# Altium schematic sheet 4 - USB, Power supply.SchDoc
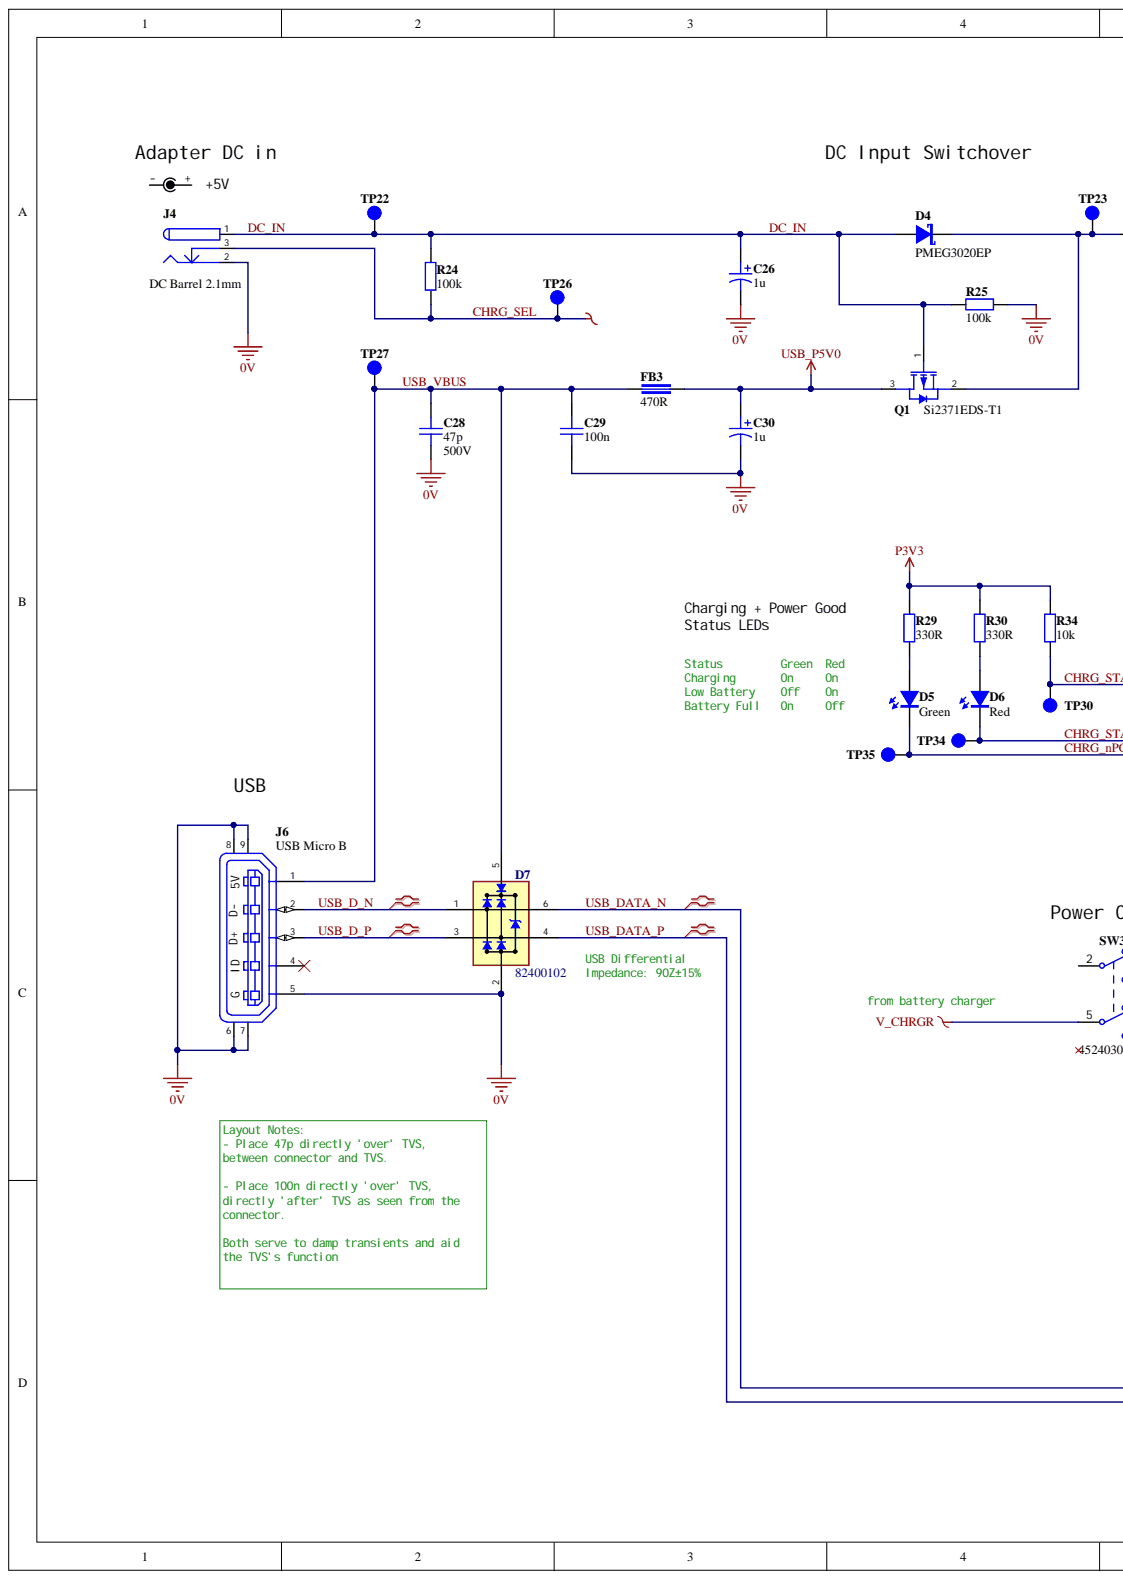
[diagram p0: 4 - USB, Power supply.SchDoc, left]
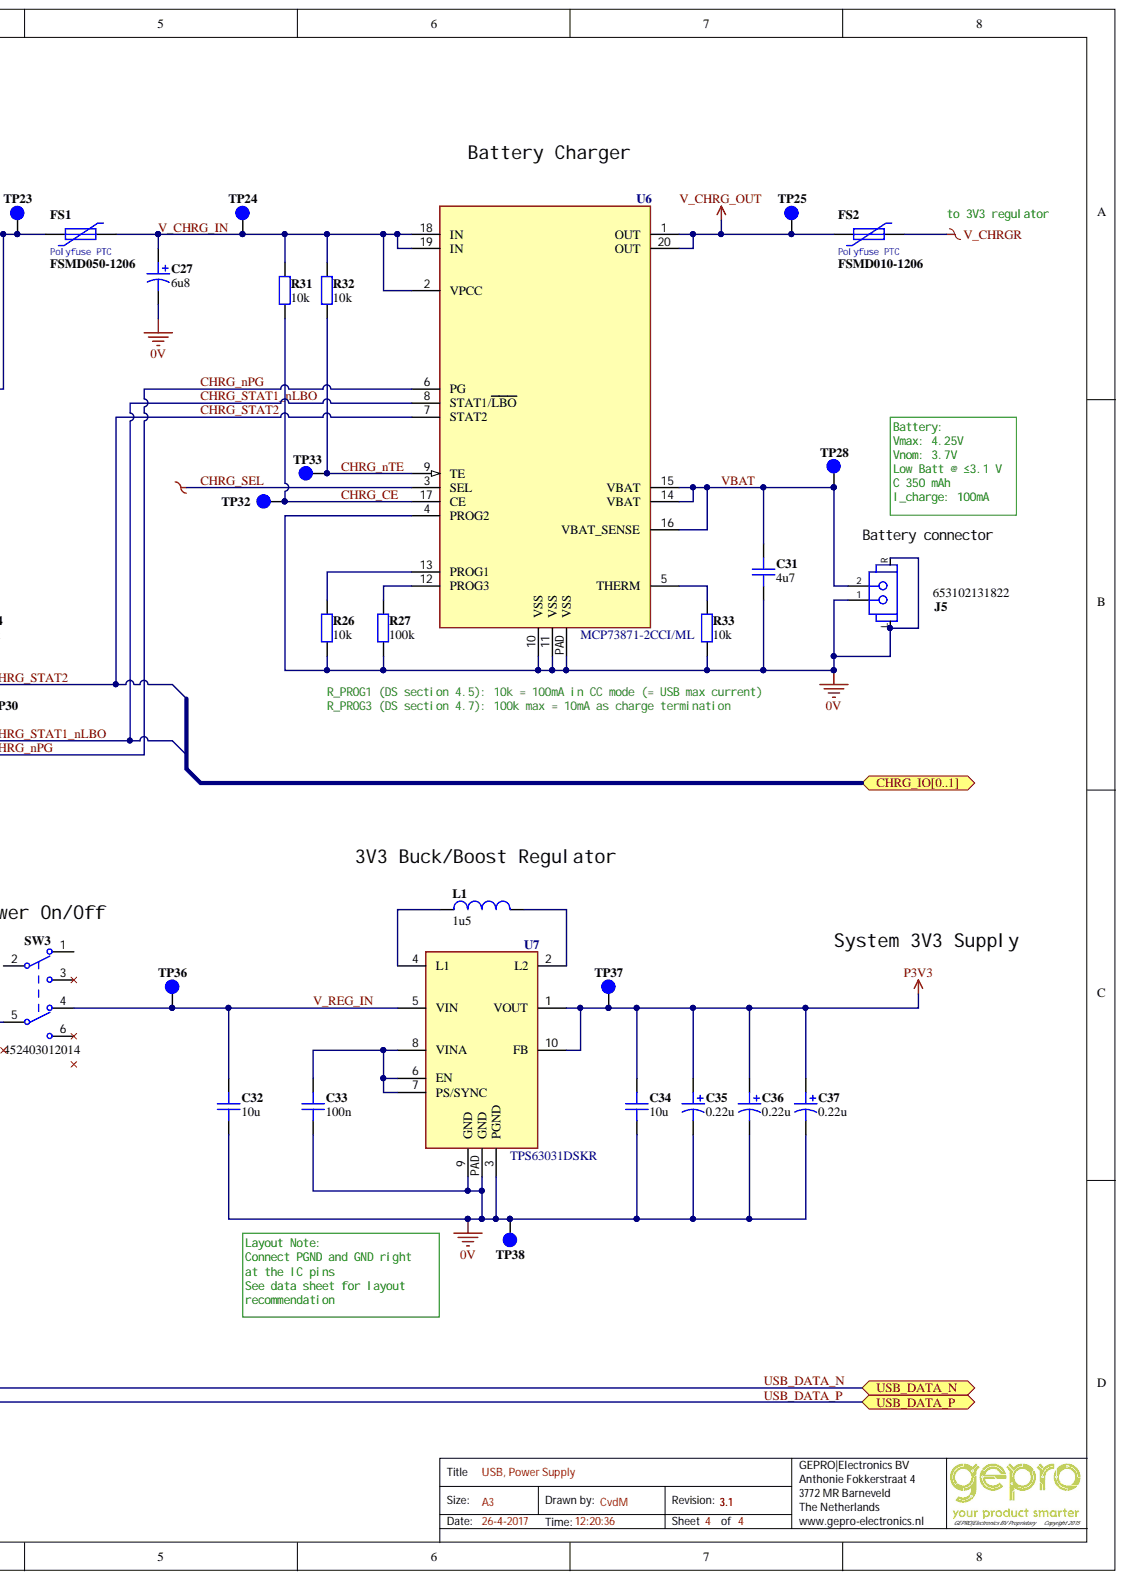
[diagram p1: 4 - USB, Power supply.SchDoc, right]

## Parts
C26  1u  [CAPAE430X550DD100_WurthElektronik_WCAP-ASLI_40x55]
C27  6u8  [CAPAE430X550DD100_WurthElektronik_WCAP-ASLI_40x55]
C28  47p  Voltage Rating: 500V  [CAPC3216X95N]
C29  100n  [CAPC1608X90N]
C30  1u  [CAPAE430X550DD100_WurthElektronik_WCAP-ASLI_40x55]
C31  4u7  [CAPC2012X150N]
C32  10u  [CAPC2012X150N]
C33  100n  [CAPC1608X90N]
C34  10u  [CAPC2012X150N]
C35  0.22u  [CAPAE430X550DD100_WurthElektronik_WCAP-ASLI_40x55]
C36  0.22u  [CAPAE430X550DD100_WurthElektronik_WCAP-ASLI_40x55]
C37  0.22u  [CAPAE430X550DD100_WurthElektronik_WCAP-ASLI_40x55]
D4  PMEG3020EP  [SODFL4725X110_NXP_SOD128]
D5  Green  [LEDSC80X160X80-2N_WurthElektronik_WL-SMCW_GREEN]
D6  Red  [LEDSC80X160X80-2N_WurthElektronik_WL-SMCW_RED]
D7  82400102  [SOT95P280X145-6L30N_WurthElektronik_SOT23-6L]
FB3  470R  [FB1608X100N]
FS1  FSMD050-1206  [FUSSC165X320X75-2N_Littelfuse_1206L075TH]
FS2  FSMD010-1206  [FUSSC165X320X75-2N_Littelfuse_1206L075TH]
J4  DC Barrel 2.1mm  Component Subtype: DC Barrel  [WurthElektronik_WR-DC_6941xx301002]
J5  653102131822  [WurthElektronik_WR-WTB_653102131822]
J6  USB Micro B  Component Subtype: USB  [WurthElektronik_WL-COM_629105136821]
L1  1u5  [WurthElektronik_WE-MAPI_4020]
Q1  Si2371EDS-T1  [SOT95P237X112-3L20_Vishay_SOT23-3_TO263]
R24  100k  [RESC1608X50N]
R25  100k  [RESC1608X50N]
R26  10k  [RESC1608X50N]
R27  100k  [RESC1608X50N]
R29  330R  [RESC1608X50N]
R30  330R  [RESC1608X50N]
R31  10k  [RESC1608X50N]
R32  10k  [RESC1608X50N]
R33  10k  [RESC1608X50N]
R34  10k  [RESC1608X50N]
SW3  452403012014  [WurthElektronik_WS-SLSV_452403012014]
TP22  Comment  [Testpoint_PAD_DIA_1mm]  (value not drawn)  (no BOM)
TP23  Comment  [Testpoint_PAD_DIA_1mm]  (value not drawn)  (no BOM)
TP24  Comment  [Testpoint_PAD_DIA_1mm]  (value not drawn)  (no BOM)
TP25  Comment  [Testpoint_PAD_DIA_1mm]  (value not drawn)  (no BOM)
TP26  Comment  [Testpoint_PAD_DIA_1mm]  (value not drawn)  (no BOM)
TP27  Comment  [Testpoint_PAD_DIA_1mm]  (value not drawn)  (no BOM)
TP28  Comment  [Testpoint_PAD_DIA_1mm]  (value not drawn)  (no BOM)
TP30  Comment  [Testpoint_PAD_DIA_1mm]  (value not drawn)  (no BOM)
TP32  Comment  [Testpoint_PAD_DIA_1mm]  (value not drawn)  (no BOM)
TP33  Comment  [Testpoint_PAD_DIA_1mm]  (value not drawn)  (no BOM)
TP34  Comment  [Testpoint_PAD_DIA_1mm]  (value not drawn)  (no BOM)
TP35  Comment  [Testpoint_PAD_DIA_1mm]  (value not drawn)  (no BOM)
TP36  Comment  [Testpoint_PAD_DIA_1mm]  (value not drawn)  (no BOM)
TP37  Comment  [Testpoint_PAD_DIA_1mm]  (value not drawn)  (no BOM)
TP38  Comment  [Testpoint_PAD_DIA_1mm]  (value not drawn)  (no BOM)
U6  MCP73871-2CCI/ML  [QFN50P400X400X100-21T270X270N_Microchip_C04-126_QFN20]
U7  TPS63031DSKR  [SON50P250X250X80-11T120X230N_TexasInstruments_DSK_VSON10]

## Ports
CHRG_IO0, CHRG_IO1, CHRG_IO[0..1], USB_DATA_N, USB_DATA_P

## Nets
0V: C26.2 C27.2 C28.2 C29.2 C30.2 C31.2 C32.2 C33.2 C34.2 C35.2 C36.2 C37.2 D7.2 J4.2 J5.1 J5.L J5.R J6.5 J6.6(G) J6.7 J6.8(5V) J6.9 R25.2 R26.2 R27.2 R33.2 TP38.1 U6.4(PROG2) U6.10(VSS) U6.11(VSS) U6.PAD(VSS) U7.3(PGND) U7.9(GND) U7.PAD(GND)
P3V3: C34.1 C35.1 C36.1 C37.1 R29.1 R30.1 R34.1 TP37.1 U7.1(VOUT) U7.10(FB)
USB_P5V0: C30.1 FB3.2 Q1.3
V_CHRG_OUT: FS2.1 TP25.1 U6.1(OUT) U6.20(OUT)
V_CHRGR: FS2.2 SW3.5
CHRG_CE: R31.2 TP32.1 U6.17(CE)
CHRG_nPG: D5.C TP35.1 U6.6(PG)
CHRG_nTE: R32.2 TP33.1 U6.9(TE)
CHRG_SEL: J4.3 R24.1 TP26.1 U6.3(SEL)
CHRG_STAT1_nLBO: D6.C TP34.1 U6.8(STAT1/LBO)
CHRG_STAT2: R34.2 TP30.1 U6.7(STAT2)
DC_IN: C26.1 D4.A J4.1 Q1.1 R24.2 R25.1 TP22.1
USB_D_N: D7.1 J6.2
USB_D_P: D7.3 J6.3
USB_DATA_N: D7.6 ; port USB_DATA_N
USB_DATA_P: D7.4 ; port USB_DATA_P
USB_VBUS: C28.1 C29.1 D7.5 FB3.1 J6.1 TP27.1
V_CHRG_IN: C27.1 FS1.2 R31.1 R32.1 TP24.1 U6.2(VPCC) U6.18(IN) U6.19(IN)
V_REG_IN: C32.1 SW3.4 TP36.1 U7.5(VIN)
VBAT: C31.1 J5.2 TP28.1 U6.14(VBAT) U6.15(VBAT) U6.16(VBAT_SENSE)
NetC33_1: C33.1 U7.6(EN) U7.7(PS/SYNC) U7.8(VINA)
NetD4_C: D4.C FS1.1 Q1.2 TP23.1
NetD5_A: D5.A R29.2
NetD6_A: D6.A R30.2
NetL1_1: L1.1 U7.4(L1)
NetL1_2: L1.2 U7.2(L2)
NetR26_1: R26.1 U6.13(PROG1)
NetR27_1: R27.1 U6.12(PROG3)
NetR33_1: R33.1 U6.5(THERM)
CHRG_IO[0..1]:

Not connected: J6 4; SW3 1 2 3 6

## Library data of the parts
C26 C30 = CAP_ALU_ELCO_1u_50V: CAP ALUM ELEC SMT 1uF 50V 20%; Manufacturer: Würth Elektronik; Manufacturer Part Number: 865090640005; Capacitance: 1µF; Component Subtype: Aluminium Electrolytic; Lifetime @ Temp: 1000 Hrs @ 85°C; Polarized?: Yes; Ripple Current Rating: 8.4mA @ 100kHz; Component Kind: Standard; Component Type: Capacitor; Operating Temperature Range: -40°C ~ 85°C; Package / Case: Radial, Can - SMD; Package Technology: SMT; Pin Count: 2; RoHS Compliant?: Yes; Tolerance: ±20%; Voltage Rating: 50V; Library Name: KISS_IoT.SchLib
C27 = CAP_ALU_ELCO_6u8_25V: CAP ALUM ELEC SMT 6.8uF 25V 20%; Manufacturer: Würth Elektronik; Manufacturer Part Number: 865080440001; Capacitance: 6.8µF; Component Subtype: Aluminium Electrolytic; Lifetime @ Temp: 2000 Hrs @ 105°C; Polarized?: Yes; Ripple Current Rating: 70mA @ 100kHz; Component Kind: Standard; Component Type: Capacitor; Operating Temperature Range: -55°C ~ 105°C; Package / Case: Radial, Can - SMD; Package Technology: SMT; Pin Count: 2; RoHS Compliant?: Yes; Tolerance: ±20%; Voltage Rating: 25V; Library Name: KISS_IoT.SchLib
C28 = CAP_MLCC_1608_C0G_47pF_500V: Capacitor MLCC 1206 (3216 Metric) C0G (NP0) 500V 47pF 5%; Manufacturer: No Preference; Manufacturer Part Number: Select according to description parameters; Package Technology: SMT; Polarized?: No; Capacitance: 47pF; Component Kind: Standard; Component Subtype: MLCC; Component Type: Capacitor; Dielectric Type: C0G (NP0); Operating Temperature Range: -55°C ~ 125°C; Package / Case: 0603 (1608 Metric); Pin Count: 2; RoHS Compliant?: Yes; Tolerance: ±5%; Library Name: KISS_IoT.SchLib
C29 C33 = CAP_MLCC_1608_X7R_100nF_50V: Capacitor MLCC 0603 (1608 Metric) X7R 50V 100nF 10%; Manufacturer: No Preference; Manufacturer Part Number: Select according to description parameters; Package Technology: SMT; Polarized?: No; Capacitance: 100nF; Component Kind: Standard; Component Subtype: MLCC; Component Type: Capacitor; Dielectric Type: X7R; Operating Temperature Range: -55°C ~ 125°C; Package / Case: 0603 (1608 Metric); Pin Count: 2; RoHS Compliant?: Yes; Tolerance: ±10%; Voltage Rating: 50V; Library Name: KISS_IoT.SchLib
C31 = CAP_MLCC_2012_X7R_4u7_10V: Capacitor MLCC 0805 (2012 Metric) X7R 10V 4.7µF 10%; Manufacturer: No Preference; Manufacturer Part Number: Select according to description parameters; Package Technology: SMT; Polarized?: No; Capacitance: 4.7µF; Component Kind: Standard; Component Subtype: MLCC; Component Type: Capacitor; Dielectric Type: X7R; Operating Temperature Range: -55°C ~ 125°C; Package / Case: 0805 (2012 Metric); Pin Count: 2; RoHS Compliant?: Yes; Tolerance: ±10%; Voltage Rating: 10V; Library Name: KISS_IoT.SchLib
C32 C34 = CAP_MLCC_2012_X7R_10uF_10V: Capacitor MLCC 0805 (2012 Metric) X7R 10V 10µF 10%; Manufacturer: No Preference; Manufacturer Part Number: Select according to description parameters; Package Technology: SMT; Polarized?: No; Capacitance: 10µF; Component Kind: Standard; Component Subtype: MLCC; Component Type: Capacitor; Dielectric Type: X7R; Operating Temperature Range: -55°C ~ 125°C; Package / Case: 0805 (2012 Metric); Pin Count: 2; RoHS Compliant?: Yes; Tolerance: ±10%; Voltage Rating: 10V; Library Name: KISS_IoT.SchLib
C35-C37 = CAP_ALU_ELCO_0u22_50V: CAP ALUM ELEC SMT 0.22uF 50V 20%; Manufacturer: Würth Elektronik; Manufacturer Part Number: 865090640002; Capacitance: 0.22µF; Component Subtype: Aluminium Electrolytic; Lifetime @ Temp: 1000 Hrs @ 85°C; Polarized?: Yes; Ripple Current Rating: 2mA @ 100kHz; Component Kind: Standard; Component Type: Capacitor; Operating Temperature Range: -40°C ~ 85°C; Package / Case: Radial, Can - SMD; Package Technology: SMT; Pin Count: 2; RoHS Compliant?: Yes; Tolerance: ±20%; Voltage Rating: 50V; Library Name: KISS_IoT.SchLib
D4 = PMEG3020EP: Diode Schottky Vf=360mV Vr=30V Id=2A; Manufacturer: NXP; Current - Average Rectified (Io) (per Diode): 2A; Current - Reverse Leakage @ Vr: 3mA @ 30V; Package Technology: SMT; Polarized?: Yes; Voltage - DC Reverse (Vr) (Max): 30V; Voltage - Forward (Vf) (Max) @ If: 360mV @ 2A; Component Kind: Standard; ComponentLink1Description: Data Sheet; ComponentLink1URL: http://www.nxp.com/documents/data_sheet/PMEG3020EP.pdf; Component Subtype: Schottky; Component Type: Diode; Manufacturer Part Number: PMEG3020EP; Operating Temperature Range: -55°C ~ 150°C; Package / Case: SOD-128; Pin Count: 2; RoHS Compliant?: Yes; Library Name: KISS_IoT.SchLib
D5 = 150060GS75000: LED 1608 3.2Vf 520nm Green; Manufacturer: Würth Elektronik; Forward Voltage (Vf) (Typ): 3.2V; Lens Style/Size: Rectangle with Flat Top, 1.10mm x 0.70mm; Milicandella Rating: 430mcd; Package Technology: SMT; Polarized?: Yes; Color: Green; Component Kind: Standard; ComponentLink1Description: Data Sheet; ComponentLink1URL: http://katalog.we-online.de/led/datasheet/150060GS75000.pdf; Component Subtype: LED; Component Type: Opto-electronics; Manufacturer Part Number: 150060GS75000; Operating Temperature Range: -40°C ~ 85°C; Package / Case: 0603 (1608 Metric); Pin Count: 2; RoHS Compliant?: Yes; Wavelength (Typ): 520nm; Library Name: KISS_IoT.SchLib
D6 = 150060RS75000: LED 1608 2.0Vf 625nm Red; Manufacturer: Würth Elektronik; Forward Voltage (Vf) (Typ): 2V; Lens Style/Size: Rectangle with Flat Top, 1.10mm x 0.70mm; Milicandella Rating: 250mcd; Package Technology: SMT; Polarized?: Yes; Color: Red; Component Kind: Standard; ComponentLink1Description: Data Sheet; ComponentLink1URL: http://katalog.we-online.de/led/datasheet/150060RS75000.pdf; Component Subtype: LED; Component Type: Opto-electronics; Manufacturer Part Number: 150060RS75000; Operating Temperature Range: -40°C ~ 85°C; Package / Case: 0603 (1608 Metric); Pin Count: 2; RoHS Compliant?: Yes; Wavelength (Typ): 625nm; Library Name: KISS_IoT.SchLib
D7 = 82400102: TVS DIODE 5V, 2 I/O Lines, Unidirectional; Manufacturer: Würth Elektronik; Channels - Number: 2; Package Technology: SMT; Polarized?: Yes; Channels - Uni/Bi Directional: Unidirectional; Component Kind: Standard; ComponentLink1Description: Data Sheet; ComponentLink1URL: http://katalog.we-online.de/pbs/datasheet/82400102.pdf; Component Subtype: TVS Diode; Component Type: Circuit Protection; Manufacturer Part Number: 82400102; Operating Temperature Range: -40°C ~ 125°C (TJ); Package / Case: SOT-23-6; Pin Count: 6; RoHS Compliant?: Yes; Voltage - Reverse Standoff (Typ): 5V; Library Name: KISS_IoT.SchLib
FB3 = 742792645: Chip Ferrite Bead 0603 (1608 Metric) 470R @ 100MHz 1A; Manufacturer: Würth Elektronik; DC Resistance (DCR): 200 mOhm; Impedance @ Frequency: 470 Ohm @ 100MHz; Package Technology: SMT; Polarized?: No; Component Kind: Standard; ComponentLink1Description: Data Sheet; ComponentLink1URL: http://katalog.we-online.com/pbs/datasheet/742792645.pdf; Component Subtype: Chip Ferrite Bead; Component Type: Filter; Current Rating: 1A; Manufacturer Part Number: 742792645; Operating Temperature Range: -55°C ~ 125°C; Package / Case: 0603 (1608 Metric); Pin Count: 2; RoHS Compliant?: Yes; Library Name: KISS_IoT.SchLib
FS1 = FSMD050-1206: Manufacturer: Fuzetec; Component Kind: Standard; Description: FSMD050-1206; Manufacturer Part Number: FSMD050-1206; Library Name: KISS_IoT.SchLib
FS2 = FSMD010-1206: Manufacturer: Fuzetec; Component Kind: Standard; Description: FSMD010-1206; Manufacturer Part Number: FSMD010-1206; Library Name: KISS_IoT.SchLib
J4 = 694106301002: DC Barrel Jack THT RA 2.1mm 24V 5A; Manufacturer: Würth Elektronik; Connector Type: DC Barrel Jack 2.1mm; Gender: Receptable; Polarized?: Yes; Voltage Rating: 24VDC; Component Kind: Standard; ComponentLink1Description: Data Sheet; ComponentLink1URL: http://katalog.we-online.de/em/datasheet/6941xx301002.pdf; Component Type: Connector; Current Rating: 5A; Manufacturer Part Number: 694106301002; Operating Temperature Range: -40°C ~ 85°C; Package Technology: THT; Pin Count: 3; RoHS Compliant?: Yes; Library Name: KISS_IoT.SchLib
J5 = 653102131822: Header 1x2 Male Receptable RA 1.25mm Pitch 1A 125VAC WR-WTB Series; Manufacturer: Würth Elektronik; Number of Positions: 2; Package Technology: SMT; Polarized?: Yes; Component Kind: Standard; ComponentLink1Description: Data Sheet; ComponentLink1URL: http://katalog.we-online.de/em/datasheet/6531xx131822.pdf; Component Subtype: Header; Component Type: Connector; Connector Type: Receptable; Current Rating: 1A; Feature: Locking; Gender: Male; Manufacturer Part Number: 653102131822; Operating Temperature Range: -40°C ~ 85°C; Orientation: Right Angle; Pin Count: 2 + 2; Pitch: 1.25mm; RoHS Compliant?: Yes; Shrouded?: Yes; Voltage Rating: 125VAC; Library Name: KISS_IoT.SchLib
J6 = 629105136821: Connector USB Micro B R/A Receptable SMT; Manufacturer: Würth Elektronik; Current Rating: 1A (signal pins), 1.8A (power pins); Number of Contacts: 5 + 4 Shield; Polarized?: Yes; Voltage Rating: 30V AC (rms); Component Kind: Standard; ComponentLink1Description: Data Sheet; ComponentLink1URL: http://katalog.we-online.com/em/datasheet/629105136821.pdf; Component Type: Connector; Gender: Receptable; Manufacturer Part Number: 629105136821; Operating Temperature Range: -40°C ~ 85°C; Package / Case: USB Micro B; Package Technology: SMT; Pin Count: 9; RoHS Compliant?: Yes; Library Name: KISS_IoT.SchLib
L1 = 74438356015: Inductor Power Shielded I=5.8A Isat=7.8A Rs=19mOhm 1.5µH 20%; Manufacturer: Würth Elektronik; Component Subtype: Power Inductor; Current Rating: 5.8A; DC Resistance (DCR): 19 mOhm Max; Package Technology: SMT; Polarized?: No; Saturation Current: 7.8A; Self-Resonant Frequency: 48MHz; Shielded?: Yes; Component Kind: Standard; ComponentLink1Description: Data Sheet; ComponentLink1URL: http://katalog.we-online.de/pbs/datasheet/74438356015.pdf; Component Type: Inductor; Inductance: 1.5µH; Manufacturer Part Number: 74438356015; Operating Temperature Range: -40°C ~ 125°C; Package / Case: 2-SMD; Pin Count: 2; RoHS Compliant?: Yes; Tolerance: ±20%; Library Name: KISS_IoT.SchLib
Q1 = Si2371EDS-T1: MOSFET P-CH Vds=-30V Vth=-1.5V Id=4.8A; Manufacturer: Vishay Siliconix; Component Subtype: MOSFET P-Channel; Current - Id (max): 4.8A @ 25°C; FET Type: P-channel Trench MOSFET; Package Technology: SMT; Polarized?: Yes; Power (Max): 1.7W; Rds(on) (Max) @ Id, Vgs: 45 mOhm @ 3.7A, 10V; Voltage - Vds: 30V; Voltage - Vgs(th) (Max) @ Id: 1.5V @ 250µA; Component Kind: Standard; ComponentLink1Description: Data Sheet; ComponentLink1URL: http://www.vishay.com/docs/63924/si2371eds.pdf; Component Type: Transistor; Manufacturer Part Number: Si2371EDS-T1; Operating Temperature Range: -55°C ~ 150°C (TJ); Package / Case: TO-236-3, SC-59, SOT-23-3; Pin Count: 3; RoHS Compliant?: Yes; Library Name: KISS_IoT.SchLib
R24 R25 R27 = (no symbol name): Resistor 0603 (1608 Metric) Thick Film 100mW 100k 1%; Manufacturer: No Preference; Manufacturer Part Number: Select according to description parameters; Component Subtype: Chip SMT; Package Technology: SMT; Polarized Footprint?: No; Resistance: 100kΩ; Temperature Coefficient: ±100 ppm/K; Voltage Rating: 75V; Component Kind: Standard; Component Type: Resistor; Operating Temperature Range: -55°C ~ 155°C; Package / Case: 0603 (1608 Metric); Pin Count: 2; Power Rating: 100mW; Resistor Type: Thick Film; RoHS Compliant?: Yes; Tolerance: 1%; Library Name: KISS_IoT.SchLib
R26 R33 R34 = (no symbol name): Resistor 0603 (1608 Metric) Thick Film 100mW 10k 1%; Manufacturer: No Preference; Manufacturer Part Number: Select according to description parameters; Component Subtype: Chip SMT; Package Technology: SMT; Polarized Footprint?: No; Resistance: 10kΩ; Temperature Coefficient: ±100 ppm/K; Voltage Rating: 75V; Component Kind: Standard; Component Type: Resistor; Operating Temperature Range: -55°C ~ 155°C; Package / Case: 0603 (1608 Metric); Pin Count: 2; Power Rating: 100mW; Resistor Type: Thick Film; RoHS Compliant?: Yes; Tolerance: 1%; Library Name: KISS_IoT.SchLib
R29 R30 = (no symbol name): Resistor 0603 (1608 Metric) Thick Film 100mW 330R 1%; Manufacturer: No Preference; Manufacturer Part Number: Select according to description parameters; Component Subtype: Chip SMT; Package Technology: SMT; Polarized Footprint?: No; Resistance: 330Ω; Temperature Coefficient: ±100 ppm/K; Voltage Rating: 75V; Component Kind: Standard; Component Type: Resistor; Operating Temperature Range: -55°C ~ 155°C; Package / Case: 0603 (1608 Metric); Pin Count: 2; Power Rating: 100mW; Resistor Type: Thick Film; RoHS Compliant?: Yes; Tolerance: 1%; Library Name: KISS_IoT.SchLib
R31 R32 = (no symbol name): Resistor 0603 (1608 Metric) Thick Film 100mW 10k 1%; Manufacturer: No Preference; Manufacturer Part Number: Select according to description parameters; Component Subtype: Chip SMT; Package Technology: SMT; Polarized Footprint?: No; Resistance: 10kΩ; Temperature Coefficient: ±100 ppm/K; Unique ID: GCD_0001470; Voltage Rating: 75V; Component Kind: Standard; Component Type: Resistor; Operating Temperature Range: -55°C ~ 155°C; Package / Case: 0603 (1608 Metric); Pin Count: 2; Power Rating: 100mW; Resistor Type: Thick Film; RoHS Compliant?: Yes; Tolerance: 1%; Library Name: KISS_IoT.SchLib
SW3 = 452403012014: Switch Slide SMT 6VDC 300mA 50mR; Manufacturer: Würth Elektronik; Circuit: DPDT; Current Rating: 300mA (DC); Polarized?: Yes; Component Kind: Standard; ComponentLink1Description: Data Sheet; ComponentLink1URL: http://katalog.we-online.com/em/datasheet/452403012014.pdf; Component Subtype: Slide; Component Type: Switch; Manufacturer Part Number: 452403012014; Operating Temperature Range: -40°C ~ 85°C; Package / Case: SMT; Package Technology: SMT; Pin Count: 6; RoHS Compliant?: Yes; Voltage Rating: 6VDC; Library Name: KISS_IoT.SchLib
TP22-TP28 TP30 TP32-TP38 = TestPoint_SMD_Pad: Test Point round pad 1mm, 2mm ring; Component Subtype: Copper Pad Round; Component Type: Test Point; Library Name: KISS_IoT.SchLib
U6 = MCP73871-2CCI/ML: Battery Charger Lithium 1 Cell 4.20Vreg 1.1A; Manufacturer: Microchip Technology; Battery Chemistry: Lithium-Ion/Polymer; Battery Pack Voltage: 4.xxV; Component Subtype: Battery Charge Controller; Number of Cells: 1; Package Technology: SMT; Polarized?: Yes; Supply Voltage: 6V; Charge Current (Max): 1.1A; Component Kind: Standard; ComponentLink1Description: Data Sheet; ComponentLink1URL: http://ww1.microchip.com/downloads/en/DeviceDoc/20002090C.pdf; Component Type: PMIC; Manufacturer Part Number: MCP73871-2CCI/ML; Operating Temperature: -40°C ~ 85°C; Package / Case: 20-VFQFN Exposed Pad; Pin Count: 20; RoHS Compliant?: Yes; Library Name: KISS_IoT.SchLib
U7 = TPS63031DSKR: Switching Regulator Buck/Boost 3.3V 1A 2.4MHz; Manufacturer: Texas Instruments; Manufacturer Package Code: DSK; Number of Outputs: 1; Package Technology: SMT; Polarized?: Yes; Topology: Buck-Boost; Component Kind: Standard; ComponentLink1Description: Data Sheet; ComponentLink1URL: http://www.ti.com/lit/ds/symlink/tps63030.pdf; Component Subtype: Switching Regulator; Component Type: Voltage Regulator; Manufacturer Part Number: TPS63031DSKR; Operating Temperature: -40°C ~ 85°C; Output Current: 1A; Output Voltage: 3.3V; Package / Case: 10-WFDFN Exposed Pad; Pin Count: 10 + Pad; RoHS Compliant?: Yes; Switching Frequency: 2.4MHz; Synchronous Rectifier: Yes; Library Name: KISS_IoT.SchLib
